# Altium schematic sheet TSAL-S01-V00-Control.SchDoc
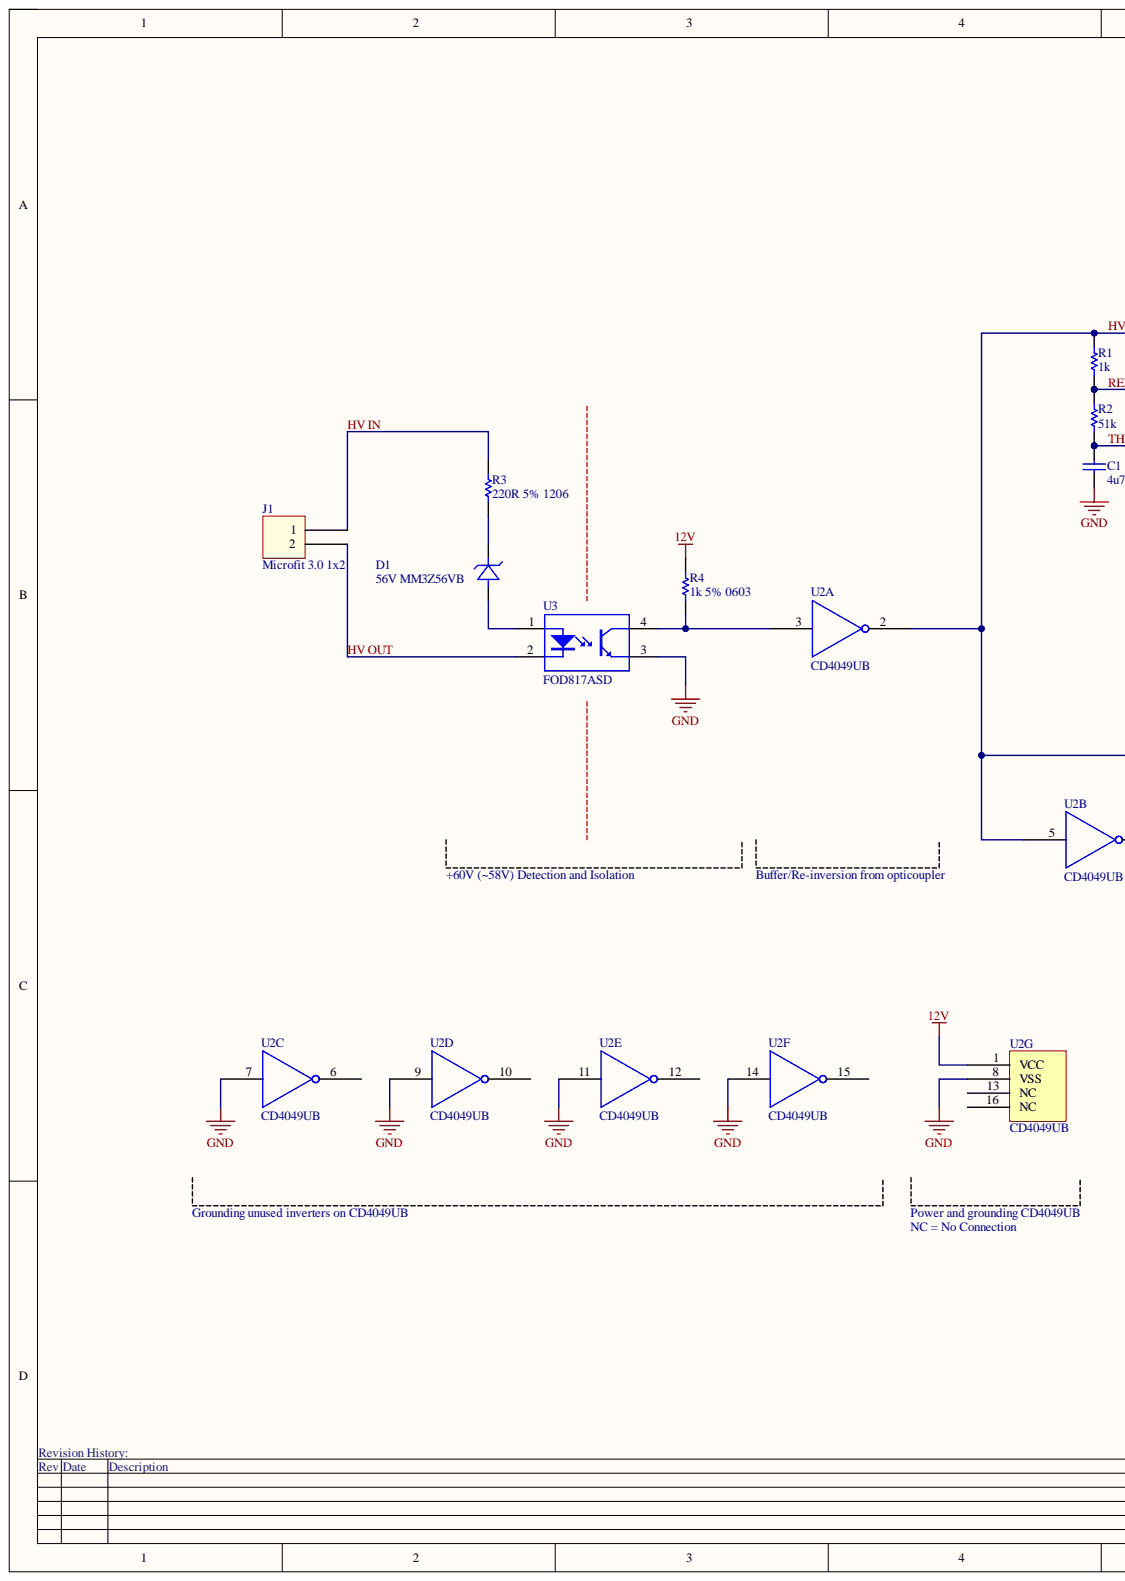
[diagram p0: TSAL-S01-V00-Control.SchDoc, left]
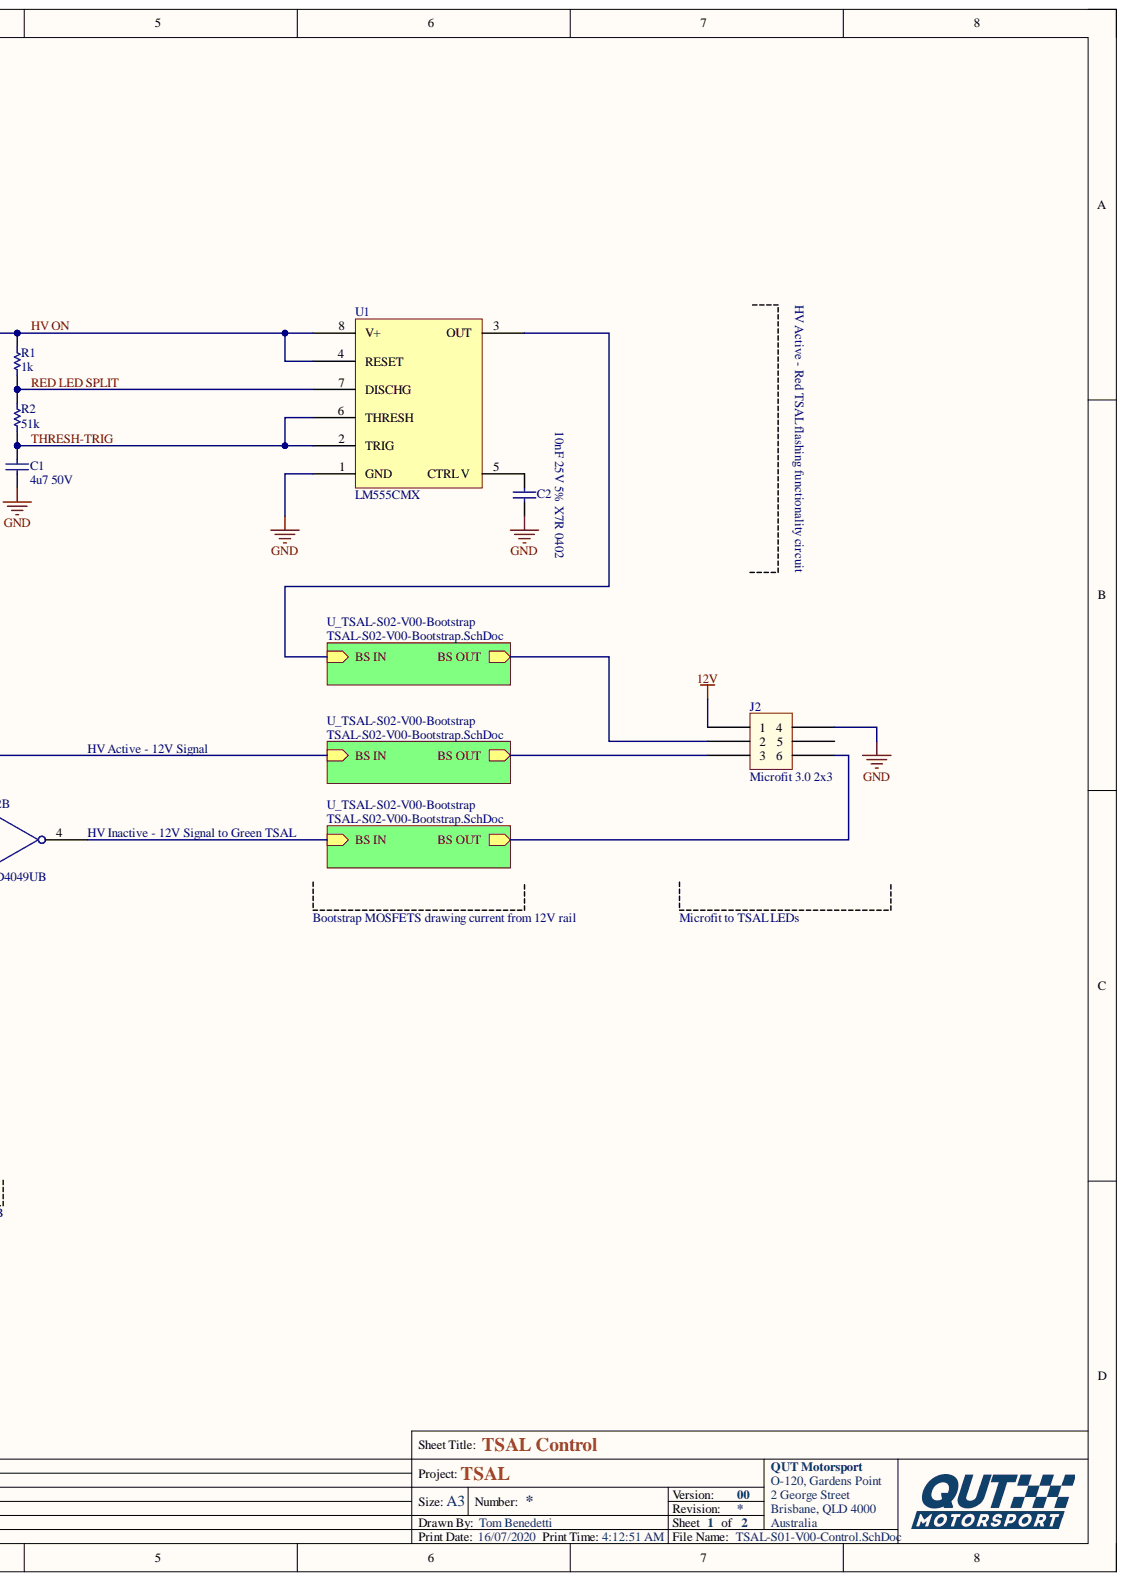
[diagram p1: TSAL-S01-V00-Control.SchDoc, right]

## Parts
C1  4u7 50V  [Cap_Ceramic_0603]
C2  10nF 25V 5% X7R 0402  [Cap_Ceramic_1206]
D1  56V MM3Z56VB  [SOD-323F]
J1  Microfit 3.0 1x2  [Microfit_1X2_R_SMD]
J2  Microfit 3.0 2x3  [Microfit_2X3_R_SMD]
R1  1k  [Res_ThinFilm_0603]
R2  51k  [Res_ThinFilm_0603]
R3  220R 5% 1206  [Res_ThinFilm_1206]
R4  1k 5% 0603  [Res_ThinFilm_0603]
U1  LM555CMX  [MCP2561 SOIC]
U2  CD4049UB  [SOIC-16]  (parts A,B,C,D,E,F,G)
U3  FOD817ASD  [FOD817ASD]

## Nets
12V: J2.1 R4.2 U2.1(VCC)
GND: C1.1 C2.1 J2.4 U1.1(GND) U2.7 U2.8(VSS) U2.9 U2.11 U2.14 U3.3
HV IN: J1.1 R3.2
HV ON: R1.2 U1.4(RESET) U1.8(V+) U2.2 U2.5
HV OUT: J1.2 U3.2
RED LED SPLIT: R1.1 R2.2 U1.7(DISCHG)
THRESH-TRIG: C1.2 R2.1 U1.2(TRIG) U1.6(THRESH)
NetC2_2: C2.2 U1.5(CTRL_V)
NetD1_A: D1.A U3.1
NetD1_K: D1.K R3.1
NetJ2_2: J2.2
NetJ2_3: J2.3
NetJ2_5: J2.5
NetJ2_6: J2.6
NetR4_1: R4.1 U2.3 U3.4
NetU1_3: U1.3(OUT)
NetU2_4: U2.4
NetU2_6: U2.6
NetU2_10: U2.10
NetU2_12: U2.12
NetU2_13: U2.13(NC)
NetU2_15: U2.15
NetU2_16: U2.16(NC)

## Library data of the parts
C1 = 4u7 50V 5% X7R 0603: Capacitor, Ceramic, SMD, 4u7, 50V, 5%, X7R, 0603; Component Kind: Standard; Library Name: StandardCapacitors.SchLib
C2 = 10nF 25V 5% X7R 0402: 10000pF ±5% 25V Ceramic Capacitor X7R 0402 (1005 Metric); Component Kind: Standard; ComponentLink1Description: Digikey; ComponentLink1URL: https://www.digikey.com/product-detail/en/murata-electronics/GRM155R71E103JA01J/490-6340-1-ND/3845537; ComponentLink2Description: Datasheet; ComponentLink2URL: https://search.murata.co.jp/Ceramy/image/img/A01X/G101/ENG/GRM155R71E103JA01-01.pdf; Library Name: StandardCapacitors.SchLib
D1 = MM3Z56VB: Zener Diode 56V 200mW ±2% Surface Mount SOD-323F; Manufacturer: ON Semiconductor; Component Kind: Standard; ComponentLink1Description: Digikey; ComponentLink1URL: https://www.digikey.com.au/product-detail/en/on-semiconductor/MM3Z56VB/MM3Z56VBCT-ND/1626897; ComponentLink2Description: Datasheet; ComponentLink2URL: https://www.onsemi.com/pub/Collateral/MM3Z9V1B-D.pdf; MPN: MM3Z56VB; Library Name: QUTMS_DiodeLibrary.SchLib
J1 = Microfit_1x2: Component Kind: Standard; Library Name: QUTMS_MicrofitLibrary.SchLib
J2 = Microfit_2x3: Component Kind: Standard; Library Name: QUTMS_MicrofitLibrary.SchLib
R1 R4 = 1k 5% 0603: Resistor, SMD, 1k, 0.1W, 5%, 0603; Component Kind: Standard; Library Name: StandardResistors.SchLib
R2 = 51k 5% 0603: 51 kOhms ±0.1% 0.063W, 1/16W Chip Resistor 0402 (1005 Metric) Automotive AEC-Q200 Thin Film; Component Kind: Standard; ComponentLink1Description: Digikey; ComponentLink1URL: https://www.digikey.com.au/product-detail/en/panasonic-electronic-components/ERA-2AEB513X/P51KDCCT-ND/1706224; ComponentLink2Description: Datasheet1; ComponentLink2URL: https://industrial.panasonic.com/cdbs/www-data/pdf/RDM0000/AOA0000C307.pdf; ComponentLink3Description: Datasheet2; ComponentLink3URL: https://media.digikey.com/pdf/Data%20Sheets/Panasonic%20Resistors%20Thermistors%20PDFs/ERA_Series_LE.pdf; ComponentLink4Description: Datasheet3; ComponentLink4URL: https://industrial.panasonic.com/cdbs/www-data/pdf/RDM0000/DMM0000COL9.pdf; Library Name: StandardResistors.SchLib
R3 = 220R 5% 1206: 220 Ohms ±1% 0.25W, 1/4W Chip Resistor 1206 (3216 Metric) Moisture Resistant Thick Film; Component Kind: Standard; ComponentLink1Description: Digikey; ComponentLink1URL: https://www.digikey.com.au/product-detail/en/yageo/RC1206FR-07220RL/311-220FRCT-ND/731640; ComponentLink2Description: Datasheet; ComponentLink2URL: https://www.yageo.com/upload/media/product/productsearch/datasheet/rchip/PYu-RC_Group_51_RoHS_L_10.pdf; Library Name: StandardResistors.SchLib
U1 = LM555CMX: 555 Type, Timer/Oscillator (Single) IC 100kHz 8-SOIC; Component Kind: Standard; ComponentLink1Description: Digikey; ComponentLink1URL: https://www.digikey.com.au/product-detail/en/texas-instruments/LM555CMX%2FNOPB/LM555CMX%2FNOPBCT-ND/1010545?utm_adgroup=Integrated%20Circuits&utm_source=google&utm_medium=cpc&utm_campaign=Dynamic%20Search_EN_Product&utm_term=&productid=&gclid=CjwKCAjwr7X4BRA4EiwAUXjbt-N3vibcCncJp7dB6lpBrLRT-QxYTJoHZmGPqFSswFIeP9Lhu7cYVBoCjmMQAvD_BwE; ComponentLink2Description: Datasheet; ComponentLink2URL: https://www.ti.com/general/docs/suppproductinfo.tsp?distId=10&gotoUrl=http%3A%2F%2Fwww.ti.com%2Flit%2Fgpn%2Flm555; Library Name: QUTMS_IntegratedCircuitLibrary.SchLib
U3 = FOD817ASD: Optoisolator 5kV Transistor 4SMD; Component Kind: Standard; Library Name: QUTMS_OctocouplerLibrary.SchLib
U2 = CD4049UB: Component Kind: Standard; Description: CD4049UB; Library Name: QUTMS_CANLibrary.SchLib
